# Altium schematic sheet SDCARD.SchDoc
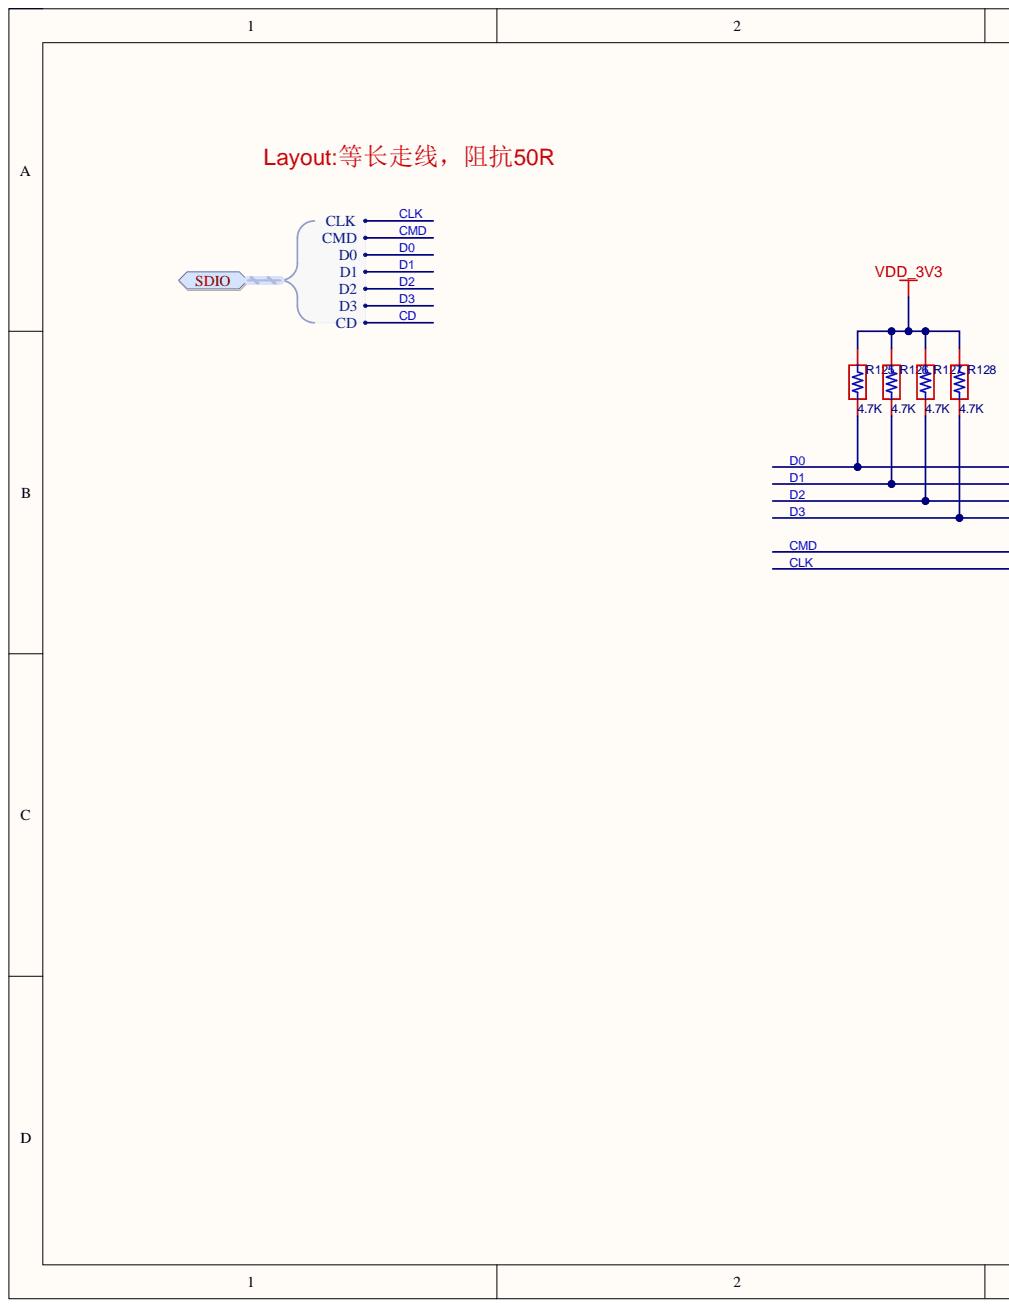
[diagram p0: SDCARD.SchDoc, left]
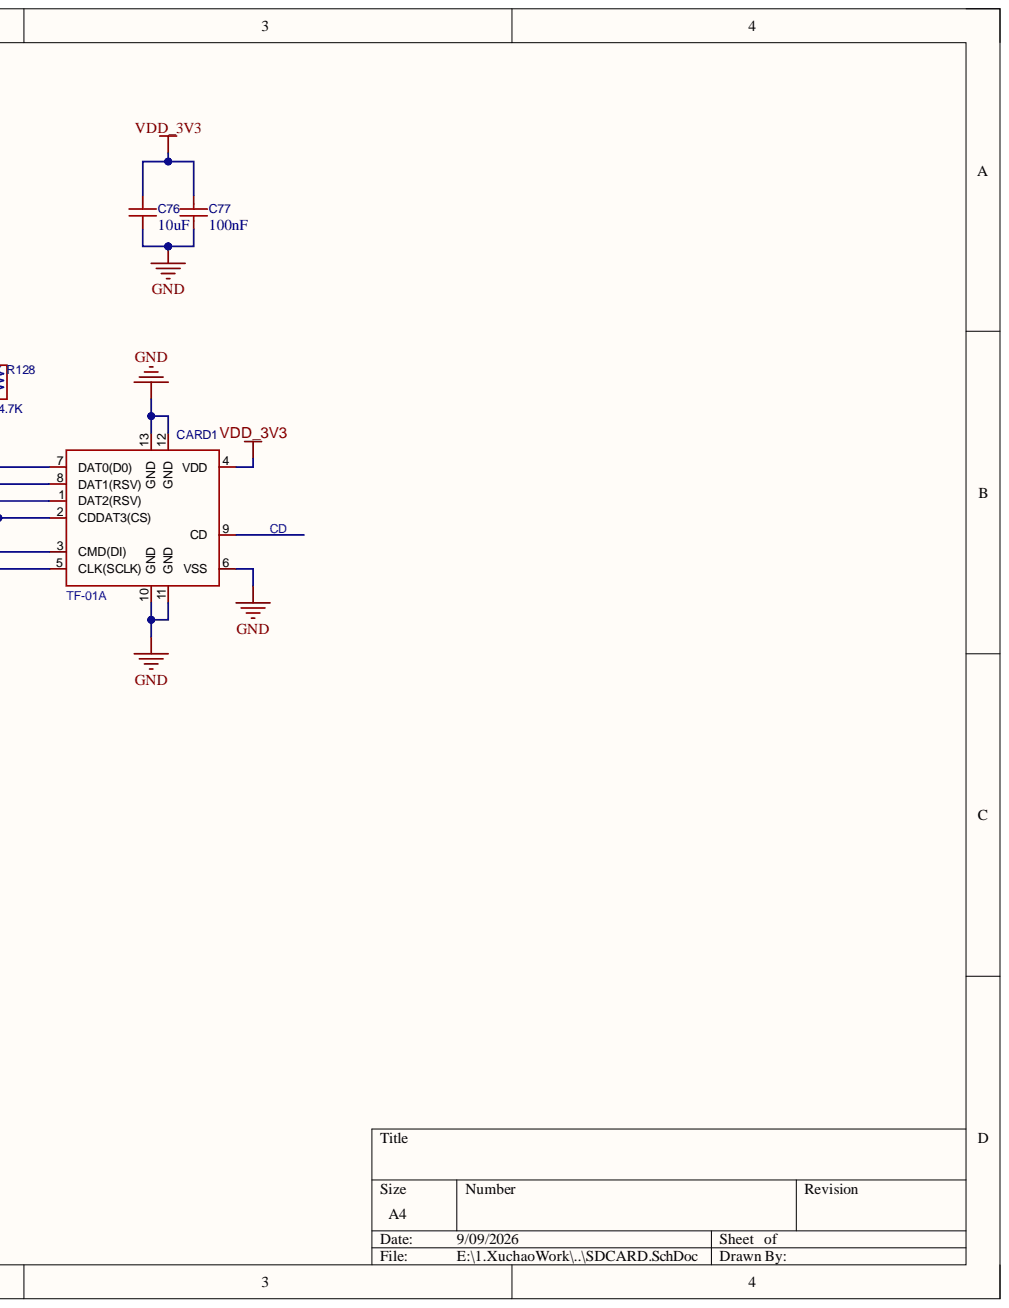
[diagram p1: SDCARD.SchDoc, right]

## Parts
C76  10uF  [C0402]
C77  100nF  [C0402]
CARD1  TF-01A  [TF-SMD_TF-01A]
R125  4.7K  [R0402]
R126  4.7K  [R0402]
R127  4.7K  [R0402]
R128  4.7K  [R0402]

## Ports
SDIO, SDIO.CD, SDIO.CLK, SDIO.CMD, SDIO.D0, SDIO.D1, SDIO.D2, SDIO.D3

## Nets
GND: C76.1 C77.1 CARD1.6(VSS) CARD1.10(GND) CARD1.11(GND) CARD1.12(GND) CARD1.13(GND)
VDD_3V3: C76.2 C77.2 CARD1.4(VDD) R125.2 R126.2 R127.2 R128.2
CD: CARD1.9(CD) ; port SDIO
CLK: CARD1.5(CLK(SCLK)) ; port SDIO
CMD: CARD1.3(CMD(DI)) ; port SDIO
D0: CARD1.7(DAT0(D0)) R125.1 ; port SDIO
D1: CARD1.8(DAT1(RSV)) R126.1 ; port SDIO
D2: CARD1.1(DAT2(RSV)) R127.1 ; port SDIO
D3: CARD1.2(CDDAT3(CS)) R128.1 ; port SDIO

## Library data of the parts
C76 C77 = 0SMD_CAP: Symbol: CL10A106KP8NNNC; Component Kind: Standard; Library Name: CYKJ_LibPkg.IntLib
CARD1 = TF-01A: MicroSD卡(TF卡); Component Kind: Standard; Symbol: TF-01A; Library Name: CYKJ_LibPkg.IntLib
R125-R128 = 0SMD_RES: Component Kind: Standard; Library Name: CYKJ_LibPkg.IntLib
